# Altium schematic sheet RampGen.SchDoc(RampGen)
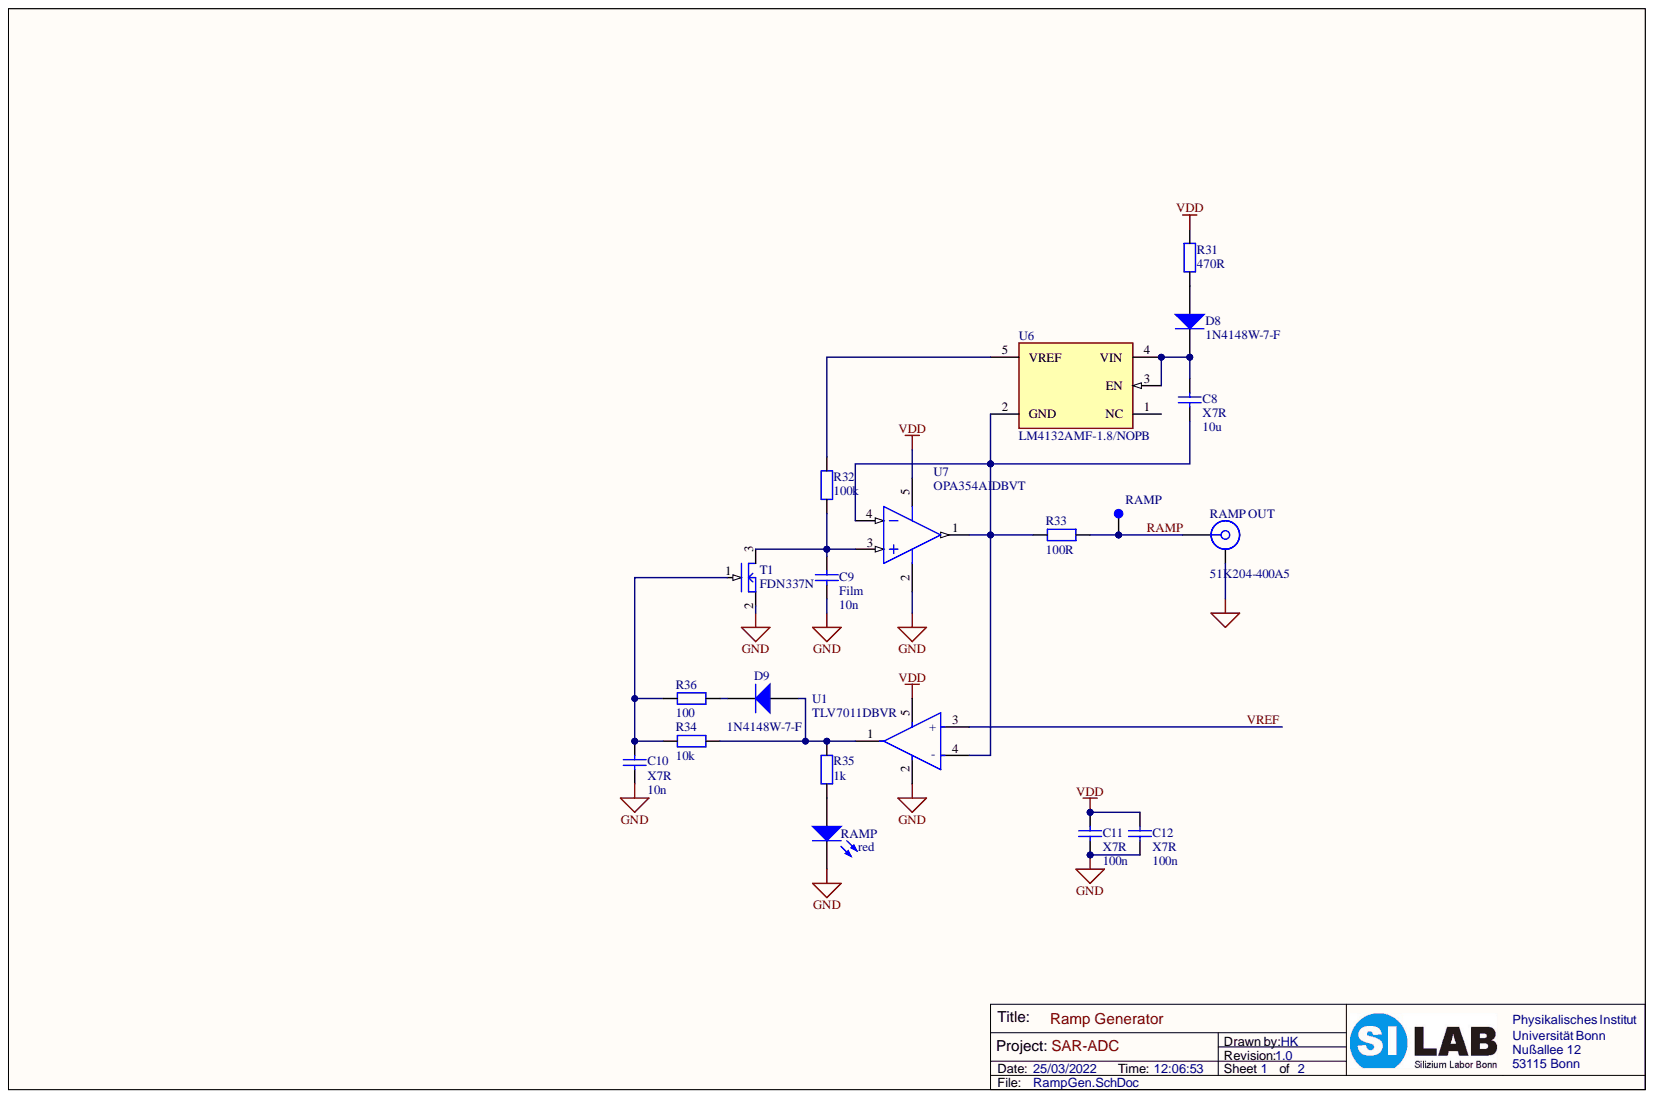
[diagram p0: RampGen.SchDoc]

## Parts
C8  X7R  value 10u  [C0603_imp_N]
C9  Film  value 10n  [C2220_imp_N]
C10  X7R  value 10n  [C0603_imp_N]
C11  X7R  value 100n  [C0603_imp_N]
C12  X7R  value 100n  [C0603_imp_N]
D8  1N4148W-7-F  [DIOD-SOD123-2_V]
D9  1N4148W-7-F  [DIOD-SOD123-2_V]
R31  R  value 470R  [R0603_imp_N]
R32  R  value 100k  [R0603_imp_N]
R33  R  value 100R  [R0603_imp_N]
R34  R  value 10k  [R0603_imp_N]
R35  R  value 1k  [R0603_imp_N]
R36  R  value 100  [R0603_imp_N]
RAMP  red  [0603_A]
RAMP  -  [Testpoint 1.0mm]  (value not drawn)
RAMP_OUT  51K204-400A5  [ROS-51K204-400A5_V]
T1  FDN337N  [SOT23]
U1  TLV7011DBVR  [FP-DBV0005A-MFG]
U6  LM4132AMF-1.8/NOPB  [FP-DBV0005A-IPC_C]
U7  OPA354AIDBVT  [DBV0005A_N]

## Nets
GND: C9.2 C10.2 C11.2 C12.2 RAMP.1 RAMP_OUT.MH T1.2 U1.2 U7.2
VDD: C11.1 C12.1 R31.1 U1.5 U7.5
RAMP: R33.2 RAMP.1 RAMP_OUT.1
VREF: U1.3(+)
NetC8_1: C8.1 D8.2 U6.3(EN) U6.4(VIN)
NetC8_2: C8.2 R33.1 U1.4(-) U6.2(GND) U7.1 U7.4
NetC9_1: C9.1 R32.2 T1.3 U7.3
NetC10_1: C10.1 R34.1 R36.1 T1.1
NetD8_1: D8.1 R31.2
NetD9_1: D9.1 R34.2 R35.1 U1.1
NetD9_2: D9.2 R36.2
NetR32_1: R32.1 U6.5(VREF)
NetR35_2: R35.2 RAMP.2

Not connected: U6 1(NC)

## Library data of the parts
C8-C12 = C: Capacitor; Class: Passive; Constraints: 6.3V; Type_1: SMD Chip Capacitor; Comment_1: -; Component Kind: Standard; HelpURL: -; LatestRevisionDate: 27-Nov-2007; PackageDescription: -; Signal Integrity: Capacitor; Simulation: Capacitor; Library Name: Silab_Lib.IntLib; Publisher: Silab J.S.
D8 D9 = CMP-2000-04932-2: Fast Switching Diode, 100 V, 0.15 A, -65 to 150 degC, 2-Pin?SOD123, RoHS, Tape and Reel; Brand: DiodesIncorporated; Configuration: Single; If - Forward Current: 0.15A; Ir - Reverse Current: 1uA; Max Surge Current: 2A; Peak Reverse Voltage: 100V; Recovery Time: 4ns; Unit Weight: 0.000353oz; Vf - Forward Voltage: 1.25V; Component Kind: Standard; Datasheet URL: http://www.farnell.com/datasheets/1915529.pdf; Datasheet Version: Rev. 24-2, 10/2013; Factory Pack Quantity: 3000; Height: 1.25mm; Length: 2.85mm; Library Name: Altium Content Vault; Manufacturer: DiodesIncorporated; Manufacturer URL: http://www.diodes.com/; Maximum Operating Temperature: +150C; Minimum Operating Temperature: -65C; Mounting Style: SMD/SMT; Operating Temperature Range: -65Cto+150C; Package / Case: SOD-123; Package Reference: SOD123-2; Package URL: http://www.diodes.com/datasheets/ds18004.pdf; Packaging: Reel; Product: SwitchingDiodes; Product Category: Diodes-GeneralPurpose,Power,Switching; RoHS: True; Series: 1N4148; Type: SwitchingDiode; Width: 1.7mm
R31-R36 = R: SMD Chip Resistor; Class: Passive; Constraints: 1%; Comment_1: -; Component Kind: Standard; HelpURL: -; LatestRevisionDate: 27-Nov-2007; PackageDescription: -; Signal Integrity: Resistor; Simulation: Resistor; Type_1: SMD Chip Resistor; Library Name: Silab_Lib.IntLib; Publisher: Silab J.S.
RAMP = CMP-1426-00001-1: SMD mono-color Chip LED, WL-SMCW, Red; Manufacturer: Wuerth Elektronik; PartNumber: 150060RS75000; Color: Red; Component Kind: Standard; ComponentLink1Description: Manufacturer URL; ComponentLink1URL: http://www.we-online.com; ComponentLink2Description: Datasheet; ComponentLink2URL: http://katalog.we-online.com/led/datasheet/150060RS75000.pdf; Library Name: Altium Content Vault; PackageReference: 0603_A
RAMP = Testpoint_gefüllt: Solderpad, Einzelner Kontakt; Class: Connector; Comment_1: -; Component Kind: Standard; Constraints: -; HelpURL: -; LatestRevisionDate: 24-Sep-2008; PackageDescription: -; Type_1: -; Value: -; Library Name: Silab_Lib.IntLib; Publisher: Silab C.H.
RAMP_OUT = CMP-0753-00004-1: BNC 50R Right Angle Jack, Panel Feedthrough, Up to 10 GHz, -55 to 155 degC; Manufacturer: Rosenberger; Design Note: Footprint in library is a generic example - only application specific footprint delivers High Performance; Component Kind: Standard; ComponentLink1Description: Manufacturer URL; ComponentLink1URL: http://www.rosenberger.de; ComponentLink2Description: Datasheet; ComponentLink2URL: http://rosenberger.de/ok/images/documents/db/51K204-400A5.pdf; ComponentLink3Description: Package Specification; ComponentLink3URL: http://rosenberger.de/ok/images/documents/db/51K204-400A5.pdf; ComponentLink4Description: Series Overview / Design Note; ComponentLink4URL: http://rosenberger.de/ok/images/documents/spez/51.pdf; ComponentLink5Description: Webshop / RFQ; ComponentLink5URL: http://www.rosenberger.com/en/contact/sales/; DatasheetVersion: d00; Library Name: Altium Content Vault; Mounting Technology: Through Hole; PackageDescription: 50R BNC Right Angle Jack, 12.5 x 32.6 x 14.5 mm; PackageReference: 51K204-400A5; PackageVersion: d00; PartNumber: 51K204-400A5
T1 = T NMOS: NMOS Transistor SMD; Class: Discrete Silicon; Type_1: FDN357N; Comment_1: -; Component Kind: Standard; Constraints: -; HelpURL: -; LatestRevisionDate: 02-Oct-2008; PackageDescription: -; Value: FDN337N; Library Name: Silab_Lib.IntLib; Publisher: Silab J.S.
U1 = CMP-04896-000099-1: VOLTAGE COMPARATOR; Component Kind: Standard; Library Name: Altium Content Vault
U6 = CMP-0074-00537-6: IC VREF SERIES 0.05% SOT23-5; Max Input Voltage: 6V; Max Output Current: 20mA; Max Output Voltage: 1.8V; Min Input Voltage: 2.2V; Nominal Supply Current: 60uA; Number of Channels: 1; Quiescent Current: 100uA; Temperature Coefficient: 10ppm/°C; Case/Package: SOT-23; Component Kind: Standard; Height: 1.02mm; Lead Free: Lead Free; Length: 2.92mm; Library Name: Altium Content Vault; Max Operating Temperature: 125°C; Min Operating Temperature: -40°C; Mount: Surface Mount; Number of Pins: 5; Output Current: 20mA; Output Voltage: 1.8V; Package Quantity: 1000; Packaging: Tape and Reel; Radiation Hardening: No; REACH SVHC: No SVHC; Reference Type: Series; Reference Voltage: 1.8V; RoHS Compliant: Yes; Series: LM4132; Supply Current: 60uA; Tolerance: 0.05%; Width: 1.6mm
U7 = CMP-0018-00402-2: 250 MHz, Rail-to-Rail I/O, CMOS Single Operational Amplifier, 2.5 to 5.5 V, -40 to 125 degC, 5-pin SOT23 (DBV5), Green (RoHS & no Sb/Br); Manufacturer: Texas Instruments; 2nd Harmonic(dBc): 75; 3rd Harmonic(dBc): 83; @ MHz: 1; BW @ Acl(MHz): 250; Diff Gain(%): 0.02; Diff Phase(deg): 0.09; Iib(Max)(nA): 0.05; Io(Typ)(mA): 100; Iq per channel(Max)(mA): 7.5; Rail-Rail: In, Out; Settling Time (0.1%)(Typ)(ns): 30; Slew Rate(Typ)(V/us): 150; Sub Family: High Output Current Amplifier (>=50mA), High Speed Amplifier (>=50MHz), Low Supply Voltage Amplifier (<=2.7V); THD (Fc is 1 MHz)(Typ)(dB): -73; Vio(Max)(mV): 8; Vn at Flatband(Typ)(nV/rtHz): 6.5; Vs(Max)(V): 5.5; Vs(Min)(V): 2.5; Acl, min stable gain(V/V): 1; Code_JEDEC: MO-178; Component Kind: Standard; ComponentLink1Description: Manufacturer URL; ComponentLink1URL: http://www.ti.com/; ComponentLink2Description: Datasheet; ComponentLink2URL: http://www.ti.com/general/docs/lit/getliterature.tsp?genericPartNumber=OPA354&fileType=pdf; ComponentLink3Description: Package Specification; ComponentLink3URL: http://www.ti.com/litv/pdf/mpds018i; DatasheetVersion: SBOS233E; Eco-Plan: Green (RoHS & no Sb/Br); Library Name: Altium Content Vault; Mounting Technology: Surface Mount; Number of Channels: 1; PackageDescription: 5-Pin Plastic Small Outline, Body 1.6 x 2.9 mm, Pitch 0.95 mm; PackageReference: DBV0005A; PackageVersion: 4073253-4/K, 03/2006; PartNumber: OPA354AIDBVT
